# Altium schematic sheet Nucleo_shield_U_cell_meterSCH.SchDoc(Nucleo_shield_U_cell_meterSCH)
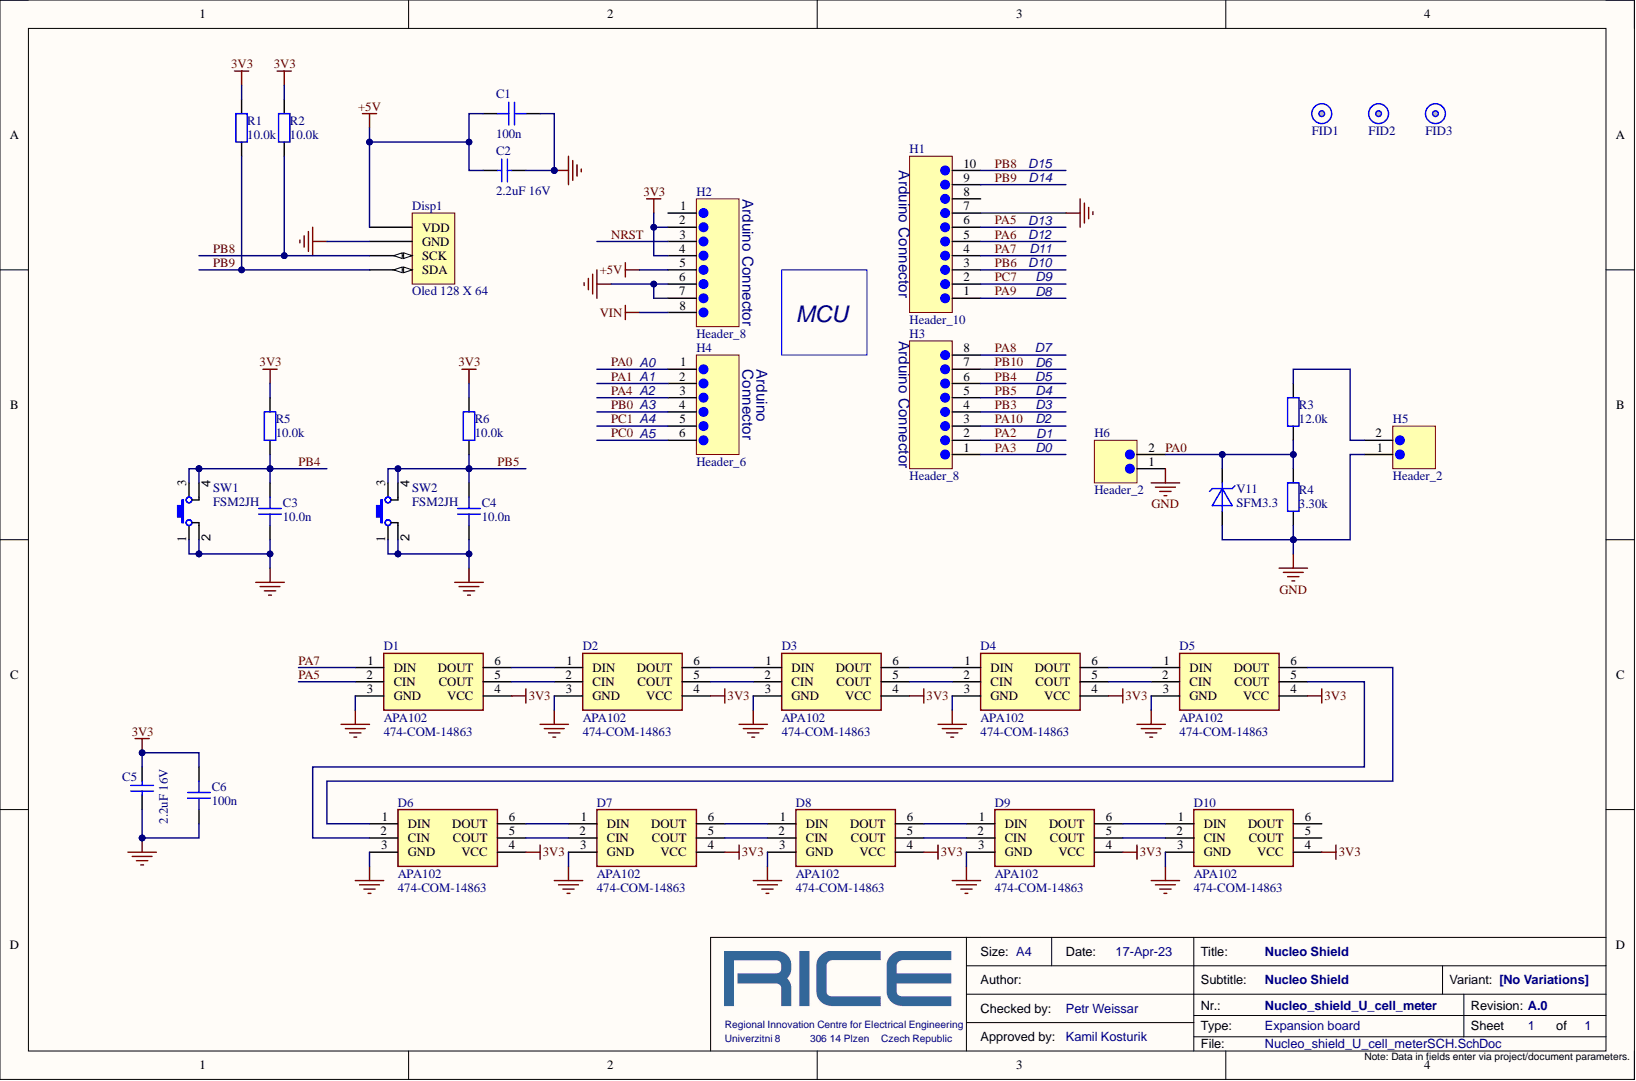
[diagram p0: Nucleo_shield_U_cell_meterSCH.SchDoc]

## Parts
C1  100n  [sm_cap_0805]
C2  2.2uF 16V  [CAPC2013X135X50ML10T20]
C3  10.0n  [sm_cap_0805]
C4  10.0n  [sm_cap_0805]
C5  2.2uF 16V  [CAPC2013X135X50ML10T20]
C6  100n  [sm_cap_0805]
D1  APA102  Supplier Part Number 1: 474-COM-14863  [WS2812]
D2  APA102  Supplier Part Number 1: 474-COM-14863  [WS2812]
D3  APA102  Supplier Part Number 1: 474-COM-14863  [WS2812]
D4  APA102  Supplier Part Number 1: 474-COM-14863  [WS2812]
D5  APA102  Supplier Part Number 1: 474-COM-14863  [WS2812]
D6  APA102  Supplier Part Number 1: 474-COM-14863  [WS2812]
D7  APA102  Supplier Part Number 1: 474-COM-14863  [WS2812]
D8  APA102  Supplier Part Number 1: 474-COM-14863  [WS2812]
D9  APA102  Supplier Part Number 1: 474-COM-14863  [WS2812]
D10  APA102  Supplier Part Number 1: 474-COM-14863  [WS2812]
Disp1  Oled 128 X 64  [TH_CON_REC1x4_PIT100_LP]
FID1  Fiducial  [SM_mch_FIDUCIAL]  (value not drawn)
FID2  Fiducial  [SM_mch_FIDUCIAL]  (value not drawn)
FID3  Fiducial  [SM_mch_FIDUCIAL]  (value not drawn)
H1  Header_10  [th_con_HDR1X10_pit100_h81m]
H2  Header_8  [TH_CON_HDR1X8_PIT100]
H3  Header_8  [TH_CON_HDR1X8_PIT100]
H4  Header_6  [TH_CON_HDR1X6_PIT100]
H5  Header_2  [th_con_HDR1X2_pit100]
H6  Header_2  [th_con_HDR1X2_pit100]
R1  10.0k  [sm_res_0805]
R2  10.0k  [sm_res_0805]
R3  12.0k  [sm_res_0805]
R4  3.30k  [sm_res_0805]
R5  10.0k  [sm_res_0805]
R6  10.0k  [sm_res_0805]
SW1  FSM2JH  [th_swi_lph]
SW2  FSM2JH  [th_swi_lph]
V11  SFM3.3  [SM_DIO_SOD123(W)]

## Nets
3V3: C5.2 C6.2 D1.4(VCC) D2.4(VCC) D3.4(VCC) D4.4(VCC) D5.4(VCC) D6.4(VCC) D7.4(VCC) D8.4(VCC) D9.4(VCC) D10.4(VCC) H2.2 H2.4 R1.2 R2.2 R5.2 R6.2
+5V: C1.2 C2.2 Disp1.1(VDD) H2.5
GND: C1.1 C2.1 C3.1 C4.1 C5.1 C6.1 D1.3(GND) D2.3(GND) D3.3(GND) D4.3(GND) D5.3(GND) D6.3(GND) D7.3(GND) D8.3(GND) D9.3(GND) D10.3(GND) Disp1.2(GND) H1.7 H2.6 H2.7 H5.1 H6.1 R4.1 SW1.1 SW1.2 SW2.1 SW2.2 V11.2
VIN: H2.8
NRST: H2.3
PA0: H4.1 H6.2 R3.1 R4.2 V11.1
PA1: H4.2
PA2: H3.2
PA3: H3.1
PA4: H4.3
PA5: D1.2(CIN) H1.6
PA6: H1.5
PA7: D1.1(DIN) H1.4
PA8: H3.8
PA9: H1.1
PA10: H3.3
PB0: H4.4
PB3: H3.4
PB4: C3.2 H3.6 R5.1 SW1.3 SW1.4
PB5: C4.2 H3.5 R6.1 SW2.3 SW2.4
PB6: H1.3
PB8: Disp1.3(SCK) H1.10 R2.1
PB9: Disp1.4(SDA) H1.9 R1.1
PB10: H3.7
PC0: H4.6
PC1: H4.5
PC7: H1.2
NetD1_5: D1.5(COUT) D2.2(CIN)
NetD1_6: D1.6(DOUT) D2.1(DIN)
NetD2_5: D2.5(COUT) D3.2(CIN)
NetD2_6: D2.6(DOUT) D3.1(DIN)
NetD3_5: D3.5(COUT) D4.2(CIN)
NetD3_6: D3.6(DOUT) D4.1(DIN)
NetD4_5: D4.5(COUT) D5.2(CIN)
NetD4_6: D4.6(DOUT) D5.1(DIN)
NetD5_5: D5.5(COUT) D6.2(CIN)
NetD5_6: D5.6(DOUT) D6.1(DIN)
NetD6_5: D6.5(COUT) D7.2(CIN)
NetD6_6: D6.6(DOUT) D7.1(DIN)
NetD7_5: D7.5(COUT) D8.2(CIN)
NetD7_6: D7.6(DOUT) D8.1(DIN)
NetD8_5: D8.5(COUT) D9.2(CIN)
NetD8_6: D8.6(DOUT) D9.1(DIN)
NetD9_5: D9.5(COUT) D10.2(CIN)
NetD9_6: D9.6(DOUT) D10.1(DIN)
NetH5_2: H5.2 R3.2

Not connected: D10 5(COUT) 6(DOUT); H1 8; H2 1

## Library data of the parts
C1 C6 = CAP_000228: CAP CER 100n 50V X7R 10% 0805; Manuf Part Number: 08055C104KAT2A; Manufacturer: AVX; Part Category: Ceramic/0805/X7R; Part Select: SCAP0001000400026100; Supp Part number: 478-1395-2-ND; Supp Part number 2: 581-08055C104K; Active: 1; Cat1: Ceramic; Cat2: 0805; Database Table Name: _Capacitors; Designer: Tomáš Košan; Exact price 2: 0; FIT: 0.100000001490116; ID: 232; LasrRevisionNote: new release; Max Oper Temperature: 125; Min Oper Temperature: -55; PCB Status: Electrical; Alternative: 1; Cat3: X7R; Component Kind: Standard; ComponentLink1Description: Datasheet; ComponentLink1URL: https://riceproject.fel.zcu.cz/Altium/PDF/passive/capacitors/xxxxC_AVX.pdf; Dielectric: X7R; Exact price: 1; Exact price 3: 0; LastRevisionDate: 11.5.2011; Library Name: Lib_Components_SQL.DbLib; ManufacturerID: AVX; ManufacturerURL: http://www.avx.com/; Part Number: CAP_000228; Part type: CAP; PartNumber: CAP_000228; PartQuantity: 1; PCB3D: sm_cap_0805; Preference: 0; Price: 0.121100001037121; Price 2: 2.4300000667572; Price 3: 0; Publishied: 11.5.2011; Quantity: 1; RoHS: 1; Simulation: cap; Stock: 166; Supplier: Digikey; Supplier2: Mouser Electronics; Supplier3: N/A; SupplierID: Digikey; SupplierID2: Mouser; SupplierID3: no supplier; SupplierURL: http://www.digikey.com/; SupplierURL2: http://www.mouser.com; SupplierURL3: N/A; Tolerance: 10%; Value: 100n; Voltage: 50V; Publisher: Libor Polacek
C2 C5 = CMP-2007-03455-1: Manufacturer: Murata; Part Number: GRM21BR71C225KA12L; Component Kind: Standard; Library Name: Altium Content Vault
C3 C4 = CAP_000175: CAP CER 10.0n 16V X7R 10% 0805; Manuf Part Number: 0805YC103KAT2A; Manufacturer: AVX; Part Category: Ceramic/0805/X7R; Part Select: SCAP0001000400025100; Supp Part number: 0805YC103KAT2A-ND; Active: 1; Cat1: Ceramic; Cat2: 0805; Database Table Name: _Capacitors; Designer: MaJa; FIT: 0.100000001490116; ID: 179; LasrRevisionNote: new release; Max Oper Temperature: 125; Min Oper Temperature: -55; PCB Status: Electrical; Alternative: 1; Cat3: X7R; Component Kind: Standard; ComponentLink1Description: Datasheet; ComponentLink1URL: https://riceproject.fel.zcu.cz/Altium/PDF/passive/capacitors/xxxxC_AVX.pdf; Dielectric: X7R; Exact price: 1; LastRevisionDate: 11.5.2011; Library Name: Lib_Components_SQL.DbLib; ManufacturerID: AVX; ManufacturerURL: http://www.avx.com/; Part Number: CAP_000175; Part type: CAP; PartNumber: CAP_000175; PartQuantity: 1; PCB3D: sm_cap_0805; Price: 0.640100002288818; Price 2: 0; Price 3: 0; Publishied: 11.5.2011; Quantity: 1; RoHS: 1; Simulation: cap; Stock: 16; Supplier: Digikey; Supplier2: N/A; Supplier3: N/A; SupplierID: Digikey; SupplierID2: no supplier; SupplierID3: no supplier; SupplierURL: http://www.digikey.com/; SupplierURL2: N/A; SupplierURL3: N/A; Tolerance: 10%; Value: 10.0n; Voltage: 16V; Publisher: Libor Polacek
D1-D10 = COM-14863: LED Tri-Color Blue/Green/Red; Manufacturer: SparkFun; Color: Blue,Green,Red; Interface: Serial; Number of LEDs: 3; Component Kind: Standard; ComponentLink1Description: https://cdn.sparkfun.com/datasheets/Components/LED/APA102C.pdf?src-supplier=Digi-Key; ComponentLink1URL: https://cdn.sparkfun.com/datasheets/Components/LED/APA102C.pdf?src-supplier=Digi-Key; Length: 5mm; Library Name: Nucleo_shield_U_cell_meterSchlib.SchLib; Manufacturer Part Number: COM-14863; Mount: Surface Mount; Pricing 1: 1=4.44000, 10=4.44000, 50=4.44000, 100=4.44000, 1000=4.44000, 10000=4.44000 (USD); RoHS: No; Stock 1: 120; Supplier 1: Mouser; Width: 5mm
Disp1 = MDOB128064WV-YBI: Graphic Oled, Cob, 128 X 64Pixel, 3.3V Rohs Compliant: Yes; Manufacturer: Midas; Category: Uncategorized; Component Kind: Standard; Library Name: Nucleo_shield_U_cell_meterSchlib.SchLib; Manufacturer Part Number: MDOB128064WV-YBI; Pricing 1: 1=306.82600, 5=280.97300, 10=269.99700, 50=240.97300 (EUR); RoHS: No; Stock 1: 77; Supplier 1: Farnell; Supplier Part Number 1: 3565084
FID1-FID3 = MCH_000004: Fiducial global circle 1mm; Manuf Part Number: x; ManufacturerID: no manufacturer; Part Category: Virtual/Fiducial; Part Select: SMCH0002000200000000; Active: 1; Cat1: Virtual; Database Table Name: _Mechanicals; Designer: Libor Polacek; Exact price: 0; ID: 1091; LastRevisionNote: new release; Alternative: 1; Cat2: Fiducial; Component Kind: Standard; ComponentLink1Description: Datasheet; FIT: 0; Function Type: fiducial; LastRevisionDate: 8.9.2011; Library Name: Lib_Components_SQL.DbLib; Max Oper Temperature: 0; Min Oper Temperature: 0; Part Number: MCH_000004; Part type: MCH; PartNumber: MCH_000004; PartQuantity: 1; PCB3D: sm_vir_fiducial; PCB Status: Virtual; Preference: 0; Price: 0; Price 2: 0; Price 3: 0; Publishied: 8.9.2011; Quantity: 1; RoHS: 1; Simulation: nosim; Stock: 0; Supp Part number: x; Supplier: N/A; Supplier2: N/A; Supplier3: N/A; SupplierID: no supplier; SupplierID2: no supplier; SupplierID3: no supplier; SupplierURL: N/A; SupplierURL2: N/A; SupplierURL3: N/A; Value: Fiducial; Publisher: Libor Polacek
H1 = CON_000542: CON 10pin header 100mill 8,1mm; Manuf Part Number: SL1113910G; Manufacturer: Fischer Elektronik; ManufacturerID: Fischer; Part Category: Pin rows/Header PIT100/Position; Part Select: TCON0001000500012100; Active: 1; Cat1: Pin rows; Cat2: Header PIT100; Cat3: Position; Database Table Name: _Connectors; Designer: Libor Polacek; Exact price: 0; FIT: 5; ID: 7996; Max Oper Temperature: 125; Min Oper Temperature: -65; PCB Status: Electrical; Alternative: 1; Component Kind: Standard; ComponentLink1Description: Datasheet; ComponentLink1URL: https://riceproject.fel.zcu.cz/Altium/PDF/Passive/Connectors/f.con.e.pdf#page=40; Design: HEADER 100mill; Exact price 2: 0; Exact price 3: 0; LatestRevisionDate: 09-Sep-2011; LatestRevisionNote: Re-released for DXP Platform.; Library Name: Lib_Components_SQL.DbLib; ManufacturerURL: http://www.fischerelektronik.de/index.php/home_en/?&L=1; Part Number: CON_000542; Part type: CON; PartNumber: CON_000542; PartQuantity: 1; PCB3D: th_con_HDR1X10_pit100; Pin: 10; Preference: 0; Price: 40; Price 2: 0; Price 3: 0; RoHS: 1; Signal Integrity: Connector; Simulation: nosim; Stock: 0; Supp Part number: SL1113910G; Supplier: Fischer Elektronik; Supplier2: N/A; Supplier3: N/A; SupplierID: Fischer; SupplierID2: no supplier; SupplierID3: no supplier; SupplierURL: http://www.fischerelektronik.de/index.php/home_en/?&L=1; SupplierURL2: N/A; SupplierURL3: N/A; Value: Header_10; Publisher: Altium Limited
H2 H3 = CON_000051: CON 8pin header 100mill 11,2mm; Manuf Part Number: SL20THR1128G; Manufacturer: Fischer Elektronik; ManufacturerID: Fischer; Part Category: Pin rows/Header PIT100/Position; Part Select: TCON0001000500011008; Active: 1; Cat1: Pin rows; Cat2: Header PIT100; Cat3: Position; Database Table Name: _Connectors; Designer: Jindrich Krivka; Exact price: 0; FIT: 4; ID: 1330; Max Oper Temperature: 125; Min Oper Temperature: -65; PCB Status: Electrical; Alternative: 1; Component Kind: Standard; ComponentLink1Description: Datasheet; ComponentLink1URL: https://riceproject.fel.zcu.cz/Altium/PDF/Passive/Connectors/f.con.e.pdf; Design: HEADER 100mill; Exact price 2: 0; Exact price 3: 0; LatestRevisionDate: 09-Sep-2011; LatestRevisionNote: Re-released for DXP Platform.; Library Name: Lib_Components_SQL.DbLib; ManufacturerURL: http://www.fischerelektronik.de/index.php/home_en/?&L=1; Part Number: CON_000051; Part type: CON; PartNumber: CON_000051; PartQuantity: 1; Pin: 8; Preference: 0; Price: 0; Price 2: 0; Price 3: 0; Published: 15.6.2011; RoHS: 1; Signal Integrity: Connector; Simulation: nosim; Stock: 0; Supp Part number: SL20THR1128G; Supplier: Fischer Elektronik; Supplier2: N/A; Supplier3: N/A; SupplierID: Fischer; SupplierID2: no supplier; SupplierID3: no supplier; SupplierURL: http://www.fischerelektronik.de/index.php/home_en/?&L=1; SupplierURL2: N/A; SupplierURL3: N/A; Value: Header_8; Publisher: Altium Limited
H4 = CON_000082: CON 6pin header 100mill 5,4mm; Manuf Part Number: SL1111206G; Manufacturer: Fischer Elektronik; ManufacturerID: Fischer; Part Category: Pin rows/Header PIT100/Position; Part Select: TCON0001000500011006; Active: 1; Cat1: Pin rows; Cat2: Header PIT100; Cat3: Position; Database Table Name: _Connectors; Designer: Tomáš Košan; Exact price: 0; FIT: 4; ID: 1881; Max Oper Temperature: 125; Min Oper Temperature: -65; PCB Status: Electrical; Alternative: 1; Component Kind: Standard; ComponentLink1Description: Datasheet; ComponentLink1URL: https://riceproject.fel.zcu.cz/Altium/PDF/Passive/Connectors/f.con.e.pdf#page=40; Design: HEADER 100mill; LatestRevisionDate: 09-Sep-2011; LatestRevisionNote: Re-released for DXP Platform.; Library Name: Lib_Components_SQL.DbLib; ManufacturerURL: http://www.fischerelektronik.de/index.php/home_en/?&L=1; Part Number: CON_000082; Part type: CON; PartNumber: CON_000082; PartQuantity: 1; Pin: 6; Preference: 0; Price: 0; Price 2: 0; Price 3: 0; Published: 15.6.2011; RoHS: 1; Signal Integrity: Connector; Simulation: nosim; Stock: 32; Supp Part number: SL1111206G; Supplier: Fischer Elektronik; Supplier2: N/A; Supplier3: N/A; SupplierID: Fischer; SupplierID2: no supplier; SupplierID3: no supplier; SupplierURL: http://www.fischerelektronik.de/index.php/home_en/?&L=1; SupplierURL2: N/A; SupplierURL3: N/A; Value: Header_6; Publisher: Altium Limited
H5 H6 = CON_000006: CON 2pin header 100mill 5,4mm; Manuf Part Number: SL1111202G; Manufacturer: Fischer Elektronik; ManufacturerID: Fischer; Part Category: Pin rows/Header PIT100/Position; Part Select: TCON0001000500011200; Active: 1; Cat1: Pin rows; Cat2: Header PIT100; Cat3: Position; Database Table Name: _Connectors; Designer: Jiri  Cengery; Exact price: 0; FIT: 2; ID: 901; LastRevisionNote: Initial version; Max Oper Temperature: 125; Min Oper Temperature: -65; PCB Status: Electrical; Alternative: 1; Component Kind: Standard; ComponentLink1Description: Datasheet; ComponentLink1URL: https://riceproject.fel.zcu.cz/Altium/PDF/Passive/Connectors/f.con.e.pdf#page=40; Design: HEADER 100mill; Exact price 2: 0; Exact price 3: 0; LastRevisionDate: 15.6.2011; Library Name: Lib_Components_SQL.DbLib; ManufacturerURL: http://www.fischerelektronik.de/index.php/home_en/?&L=1; Part Number: CON_000006; Part type: CON; PartNumber: CON_000006; PartQuantity: 1; PCB3D: th_con_HDR1X2; Pin: 2; Preference: 0; Price: 0; Price 2: 0; Price 3: 0; Published: 15.6.2011; RoHS: 1; Signal Integrity: Connector; Simulation: nosim; Stock: 21; Supp Part number: SL1111202G; Supplier: Fischer Elektronik; Supplier2: N/A; Supplier3: N/A; SupplierID: Fischer; SupplierID2: no supplier; SupplierID3: no supplier; SupplierURL: http://www.fischerelektronik.de/index.php/home_en/?&L=1; SupplierURL2: N/A; SupplierURL3: N/A; Value: Header_2; Publisher: Turjanica
R1 R2 R5 R6 = RES_000267: RES 0805 10.0k 1% 100ppm SMD thick film; Manuf Part Number: ERJ6ENF1002V; Manufacturer: Panasonic; Part Category: Thick film/0805; Part Select: SRES0001000400005100; Supp Part number: P10.0kCTR-ND; Supp Part number 2: 667-ERJ-6ENF1002V; Active: 1; Cat2: 0805; Database Table Name: _Resistors; Designer: Tomáš Košan; Exact price 2: 0; FIT: 0.100000001490116; ID: 628; LastRevisionNote: new release; Max Oper Temperature: 125; Min Oper Temperature: -55; PCB Status: Electrical; Power: 125mW; Alternative: 1; Cat1: Thick film; Component Kind: Standard; ComponentLink1Description: Datasheet; ComponentLink1URL: https://riceproject.fel.zcu.cz/Altium/PDF/passive/resistors/ERJ_EKF_Panasonic.pdf; Exact price: 1; Exact price 3: 0; LastRevisionDate: 11.5.2011; Library Name: Lib_Components_SQL.DbLib; ManufacturerID: Panasonic; ManufacturerURL: http://www.industrial.panasonic.com/; Part Number: RES_000267; Part type: RES; PartNumber: RES_000267; PartQuantity: 1; PCB3D: sm_res_0805; Preference: 0; Price: 0.0900000035762787; Price 2: 2.4300000667572; Price 3: 0; Published: 11.5.2011; Quantity: 1; RoHS: 1; Simulation: res; Stock: 4990; Supplier: Digikey; Supplier2: Mouser Electronics; Supplier3: N/A; SupplierID: Digikey; SupplierID2: Mouser; SupplierID3: no supplier; SupplierURL: http://www.digikey.com/; SupplierURL2: http://www.mouser.com; SupplierURL3: N/A; Thermal Stability: 100ppm; Tolerance: 1%; Value: 10.0k; Publisher: Libor Polacek
R3 = RES_000269: RES 0805 12.0k 1% 100ppm SMD thick film; Manuf Part Number: ERJ6ENF1202V; Manufacturer: Panasonic; Part Category: Thick film/0805; Part Select: SRES0001000400005120; Supp Part number: P12.0kCTR-ND; Active: 1; Cat2: 0805; Database Table Name: _Resistors; Designer: Kamil Kosturik; Exact price 2: 0; FIT: 0.100000001490116; ID: 630; LastRevisionNote: new release; Max Oper Temperature: 125; Min Oper Temperature: -55; PCB Status: Electrical; Power: 125mW; Alternative: 1; Cat1: Thick film; Component Kind: Standard; ComponentLink1Description: Datasheet; ComponentLink1URL: https://riceproject.fel.zcu.cz/Altium/PDF/passive/resistors/ERJ_EKF_Panasonic.pdf; Exact price: 1; Exact price 3: 0; LastRevisionDate: 11.5.2011; Library Name: Lib_Components_SQL.DbLib; ManufacturerID: Panasonic; ManufacturerURL: http://www.industrial.panasonic.com/; Part Number: RES_000269; Part type: RES; PartNumber: RES_000269; PartQuantity: 1; PCB3D: sm_res_0805; Preference: 0; Price: 0.0900000035762787; Price 2: 0; Price 3: 0; Published: 11.5.2011; Quantity: 1; RoHS: 1; Simulation: res; Stock: 0; Supplier: Digikey; Supplier2: N/A; Supplier3: N/A; SupplierID: Digikey; SupplierID2: no supplier; SupplierID3: no supplier; SupplierURL: http://www.digikey.com/; SupplierURL2: N/A; SupplierURL3: N/A; Thermal Stability: 100ppm; Tolerance: 1%; Value: 12.0k; Publisher: Libor Polacek
R4 = RES_000255: RES 0805 3.30k 1% 100ppm SMD thick film; Manuf Part Number: ERJ6ENF3301V; Manufacturer: Panasonic; Part Category: Thick film/0805; Part Select: SRES0001000400004330; Supp Part number: P3.30kCTR-ND; Supp Part number 2: 667-ERJ-6ENF3301V; Active: 1; Cat2: 0805; Database Table Name: _Resistors; Designer: Tomáš Košan; Exact price 2: 0; FIT: 0.100000001490116; ID: 616; LastRevisionNote: new release; Max Oper Temperature: 125; Min Oper Temperature: -55; PCB Status: Electrical; Power: 125mW; Alternative: 1; Cat1: Thick film; Component Kind: Standard; ComponentLink1Description: Datasheet; ComponentLink1URL: https://riceproject.fel.zcu.cz/Altium/PDF/passive/resistors/ERJ_EKF_Panasonic.pdf; Exact price: 1; Exact price 3: 0; LastRevisionDate: 11.5.2011; Library Name: Lib_Components_SQL.DbLib; ManufacturerID: Panasonic; ManufacturerURL: http://www.industrial.panasonic.com/; Part Number: RES_000255; Part type: RES; PartNumber: RES_000255; PartQuantity: 1; PCB3D: sm_res_0805; Preference: 0; Price: 0.0900000035762787; Price 2: 0; Price 3: 0; Published: 11.5.2011; Quantity: 1; RoHS: 1; Simulation: res; Stock: 80; Supplier: Digikey; Supplier2: Mouser Electronics; Supplier3: N/A; SupplierID: Digikey; SupplierID2: Mouser; SupplierID3: no supplier; SupplierURL: http://www.digikey.com/; SupplierURL2: http://www.mouser.com; SupplierURL3: N/A; Thermal Stability: 100ppm; Tolerance: 1%; Value: 3.30k; Publisher: Libor Polacek
SW1 SW2 = SWI_000006: MCH button switch; Manufacturer: TE connectivity; Part Category: Button/Closing; Part Select: TSWI0002000100000000; Supp Part number: 1555981; Active: 1; Cat2: Closing; Database Table Name: _Switches; Designer: Jiri Cengery; Exact price 2: 0; FIT: 5; ID: 2202; Max Oper Temperature: 85; Min Oper Temperature: -40; PCB Status: Electrical; Alternative: 1; Cat1: Button; Component Kind: Standard; ComponentLink1Description: Datasheet; ComponentLink1URL: https://riceproject.fel.zcu.cz/Altium/PDF/Passive/Switches/typ_LPV.pdf; ComponentLink2URL: https://riceproject.fel.zcu.cz/Altium/PDF/Passive/Switches/TE_SWITCHES_CORE_PROGRAM_CATALOG_0308.pdf; Design: button; Exact price: 1; Exact price 3: 0; LatestRevisionDate: 17-Jul-2002; LatestRevisionNote: Re-released for DXP Platform.; Library Name: Lib_Components_SQL.DbLib; Manuf Part Number: FSM2JH; ManufacturerID: TE connectivity; ManufacturerURL: http://www.te.com/en/home.html; Part Number: SWI_000006; Part type: SWI; PartNumber: SWI_000006; PartQuantity: 1; Preference: 0; Price: 2; Price 2: 0; Price 3: 0; Published: 24-Mar-1999; RoHS: 1; Simulation: nosim; Stock: 0; Supplier: Farnell; Supplier2: N/A; Supplier3: N/A; SupplierID: Farnell; SupplierID2: no supplier; SupplierID3: no supplier; SupplierURL: http://cz.farnell.com/; SupplierURL2: N/A; SupplierURL3: N/A; Value: FSM2JH; Publisher: Altium Limited
V11 = PDV_000135: TVS 3.3V Vbr=4.3V 200W unidir; Manuf Part Number: SMF3.3; Manufacturer: Littelfuse Inc.; ManufacturerID: Littelfuse; Part Category: TVS - semicon/UniDir; Part Select: SPDV0004000100000000; Supp Part number: F7701CT-ND; Active: 1; Cat1: TVS - semicon; Database Table Name: _Protect_devices; Designer: Libor Polacek; Exact price 3: 0; ID: 6140; LastRevisionNote: new release; Marking: 33; Max Oper Temperature: 150; Min Oper Temperature: -55; PCB Status: Electrical; Alternative: 1; Cat2: UniDir; Component Kind: Standard; ComponentLink1Description: Datasheet; ComponentLink1URL: https://riceproject.fel.zcu.cz/Altium/PDF/Semiconductor/Analog/Diode/Protect/littelfuse_tvs_diode_smf3_3_datasheet.pdf; Current: 200W; Exact price: 1; Exact price 2: 1; FIT: 1; LastRevisionDate: 1.10.2012; Library Name: Lib_Components_SQL.DbLib; ManufacturerURL: http://www.littelfuse.com; Part Number: PDV_000135; Part type: PDV; PartNumber: PDV_000135; PartQuantity: 1; Preference: 0; Price: 10; Price 2: 0; Price 3: 0; Published: 1.10.2012; RoHS: 1; Simulation: nosim; Stock: 43; Supplier: Digikey; Supplier2: N/A; Supplier3: N/A; SupplierID: Digikey; SupplierID2: no supplier; SupplierID3: no supplier; SupplierURL: http://www.digikey.com/; SupplierURL2: N/A; SupplierURL3: N/A; Value: SFM3.3; Voltage: 3.3V; Publisher: Martin Jára
